# Altium schematic sheet CurrentSensor.SchDoc(CurrentSensor)
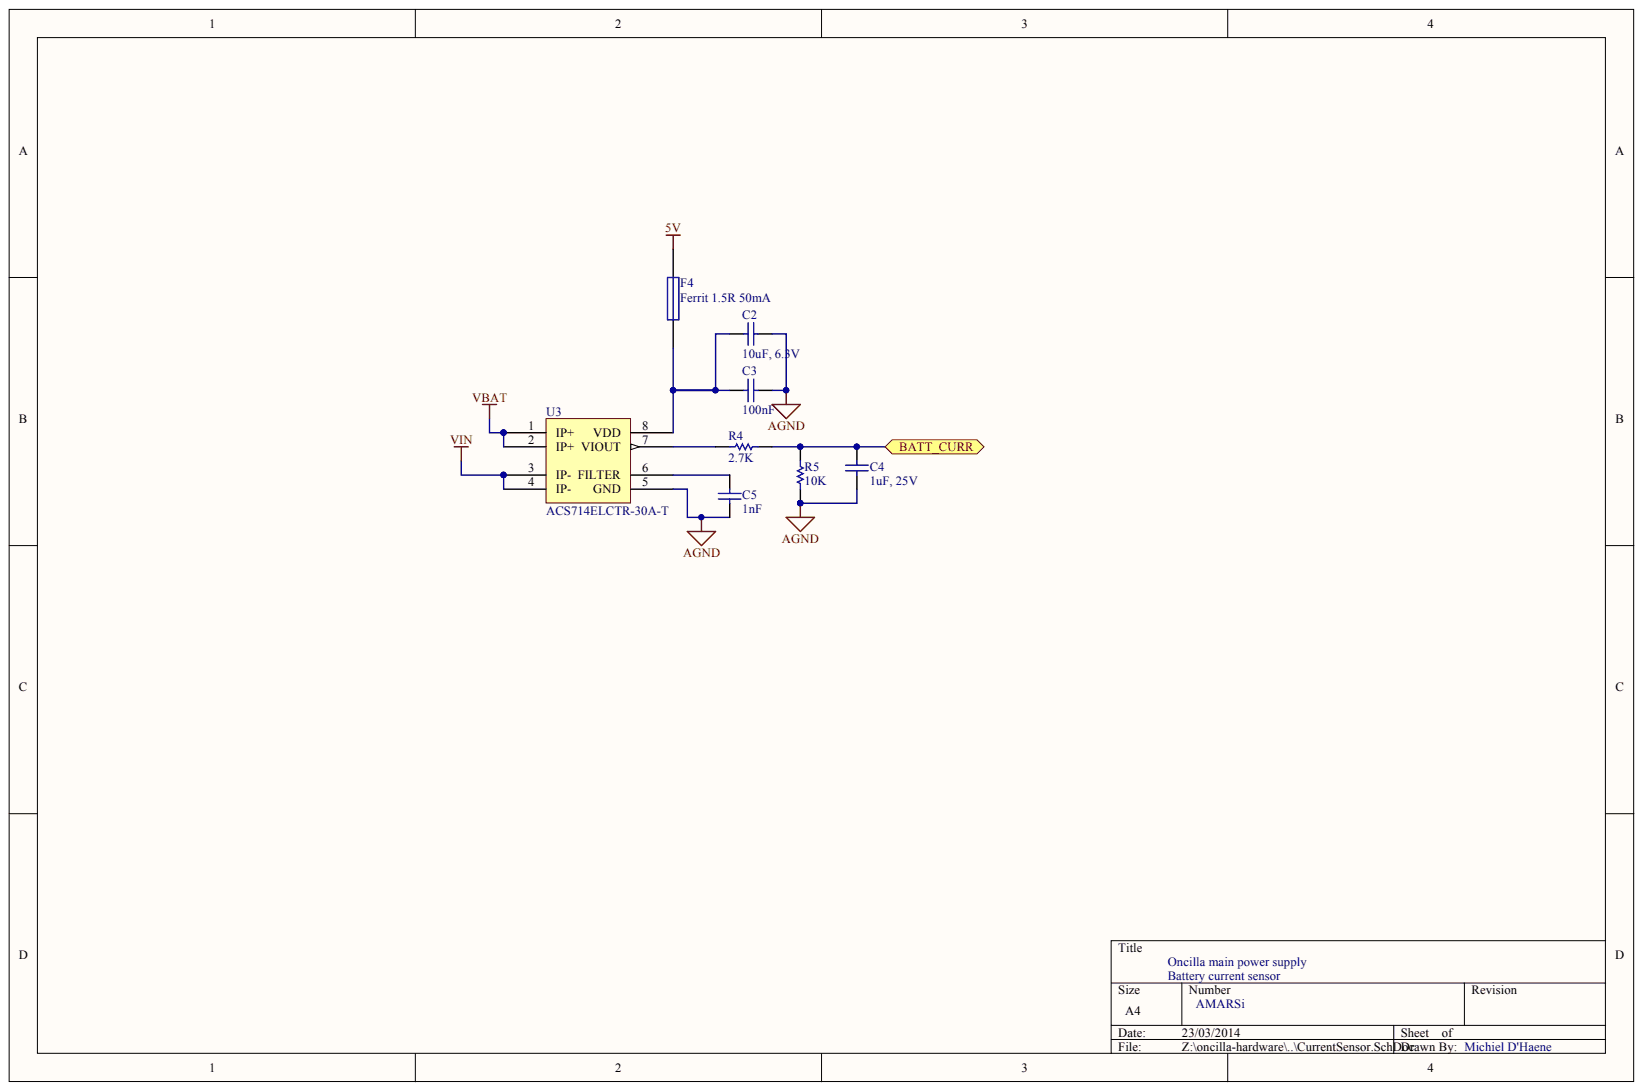
[diagram p0: CurrentSensor.SchDoc]

## Parts
C2  10uF, 6.3V
C3  100nF
C4  1uF, 25V
C5  1nF
F4  Ferrit 1.5R 50mA
R4  2.7k
R5  10k
U3  ACS714ELCTR-30A-T

## Ports
BATT_CURR

## Nets
5V: F4.2
AGND: C2.2 C3.2 C4.1 C5.1 R5.1 U3.5(GND)
VBAT: U3.1(IP+) U3.2(IP+)
VIN: U3.3(IP-) U3.4(IP-)
BATT_CURR: C4.2 R4.1 R5.2 ; port BATT_CURR
NetC2_1: C2.1 C3.1 F4.1 U3.8(VDD)
NetC5_2: C5.2 U3.6(FILTER)
NetR4_2: R4.2 U3.7(VIOUT)

## Library data of the parts
C2-C5 F4 R4 R5 U3 = (no symbol name)
  C2: Published: 8-Jun-2000; LatestRevisionDate: 29-May-2009; LatestRevisionNote: IPC-7351 Footprint Added.; PackageReference: C1206; PackageDescription: Chip Capacitor; Value: 10uF, 6.3V
  C3: Published: 8-Jun-2000; LatestRevisionDate: 29-May-2009; LatestRevisionNote: IPC-7351 Footprint Added.; PackageReference: C1206; PackageDescription: Chip Capacitor; Value: 100nF
  C4: Published: 8-Jun-2000; LatestRevisionDate: 29-May-2009; LatestRevisionNote: IPC-7351 Footprint Added.; PackageReference: C1206; PackageDescription: Chip Capacitor; Value: 1uF, 25V
  C5: Published: 8-Jun-2000; LatestRevisionDate: 29-May-2009; LatestRevisionNote: IPC-7351 Footprint Added.; PackageReference: C1206; PackageDescription: Chip Capacitor; Value: 1nF
  F4: Published: 8-Jun-2000; LatestRevisionDate: 17-Jul-2002; LatestRevisionNote: Re-released for DXP Platform.; PackageReference: PIN-W2/E2.8
  R4: Published: 8-Jun-2000; LatestRevisionDate: 17-Jul-2002; LatestRevisionNote: Re-released for DXP Platform.; PackageReference: AXIAL-0.5; Value: 2.7K
  R5: Published: 8-Jun-2000; LatestRevisionDate: 17-Jul-2002; LatestRevisionNote: Re-released for DXP Platform.; PackageReference: AXIAL-0.5; Value: 10K
  C2-C5 F4 R4 R5: Publisher: Altium Limited
